# Altium schematic sheet Sheet1.SchDoc
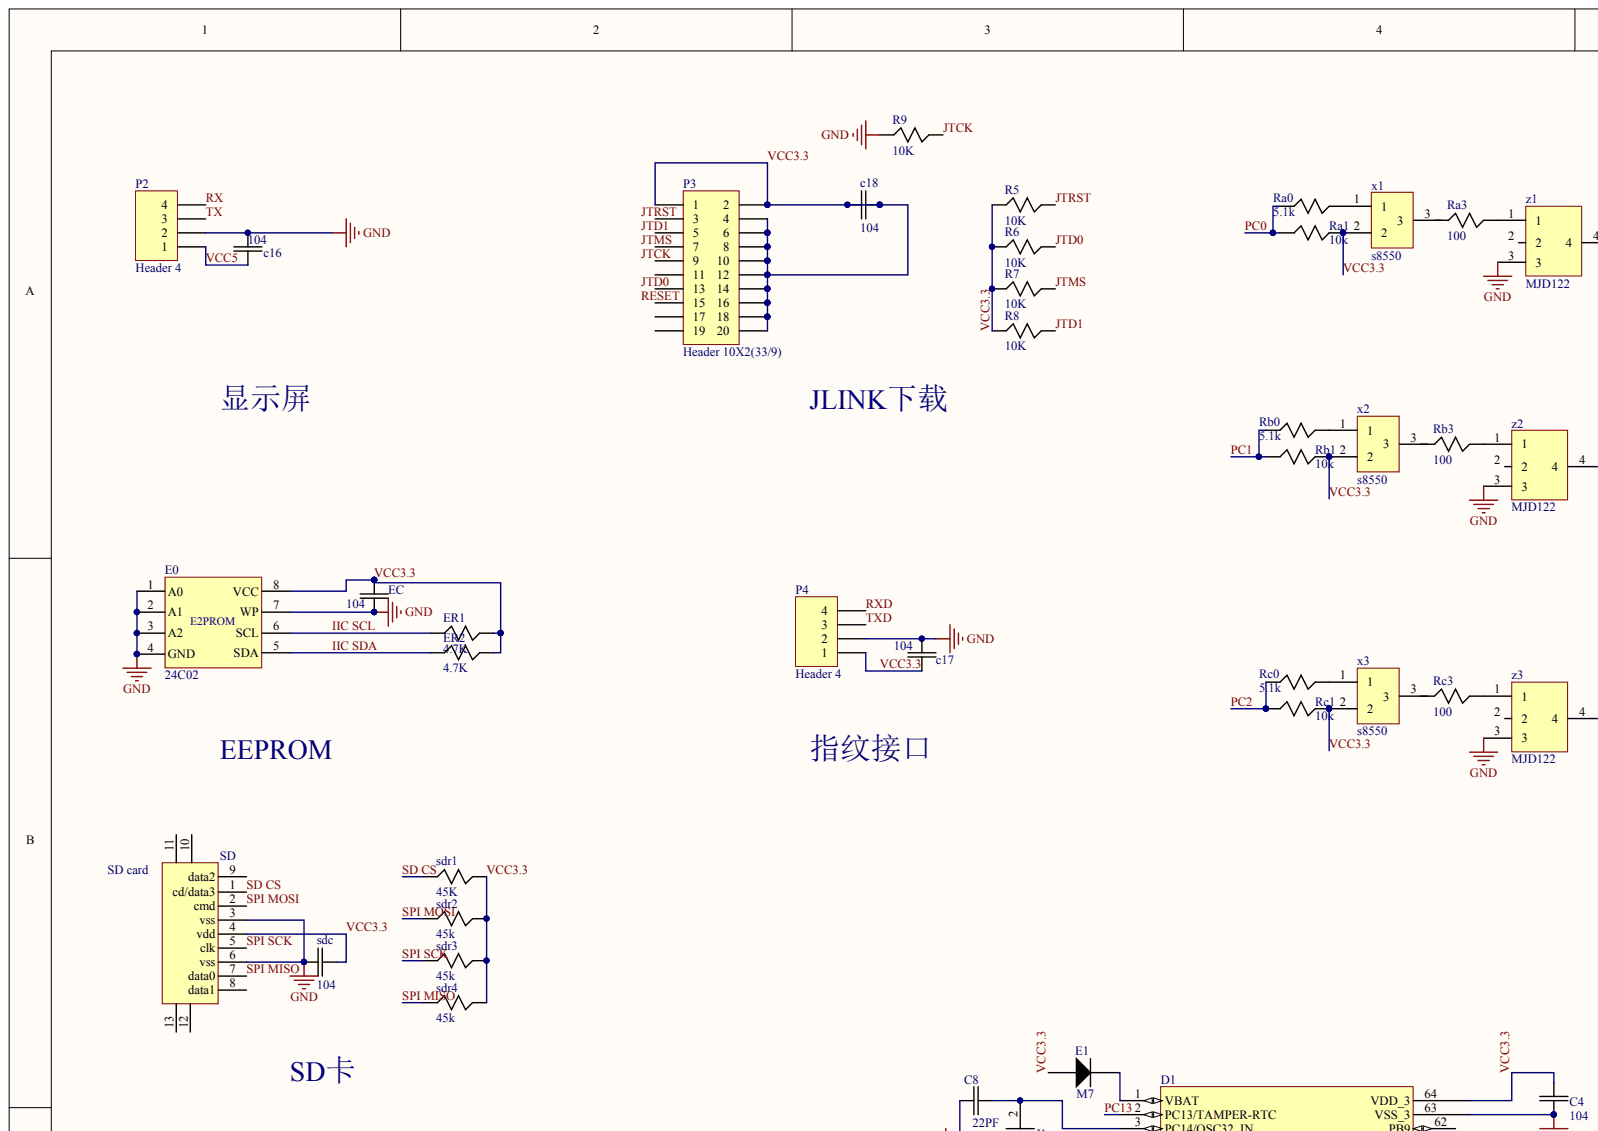
[diagram p0: Sheet1.SchDoc, top left]
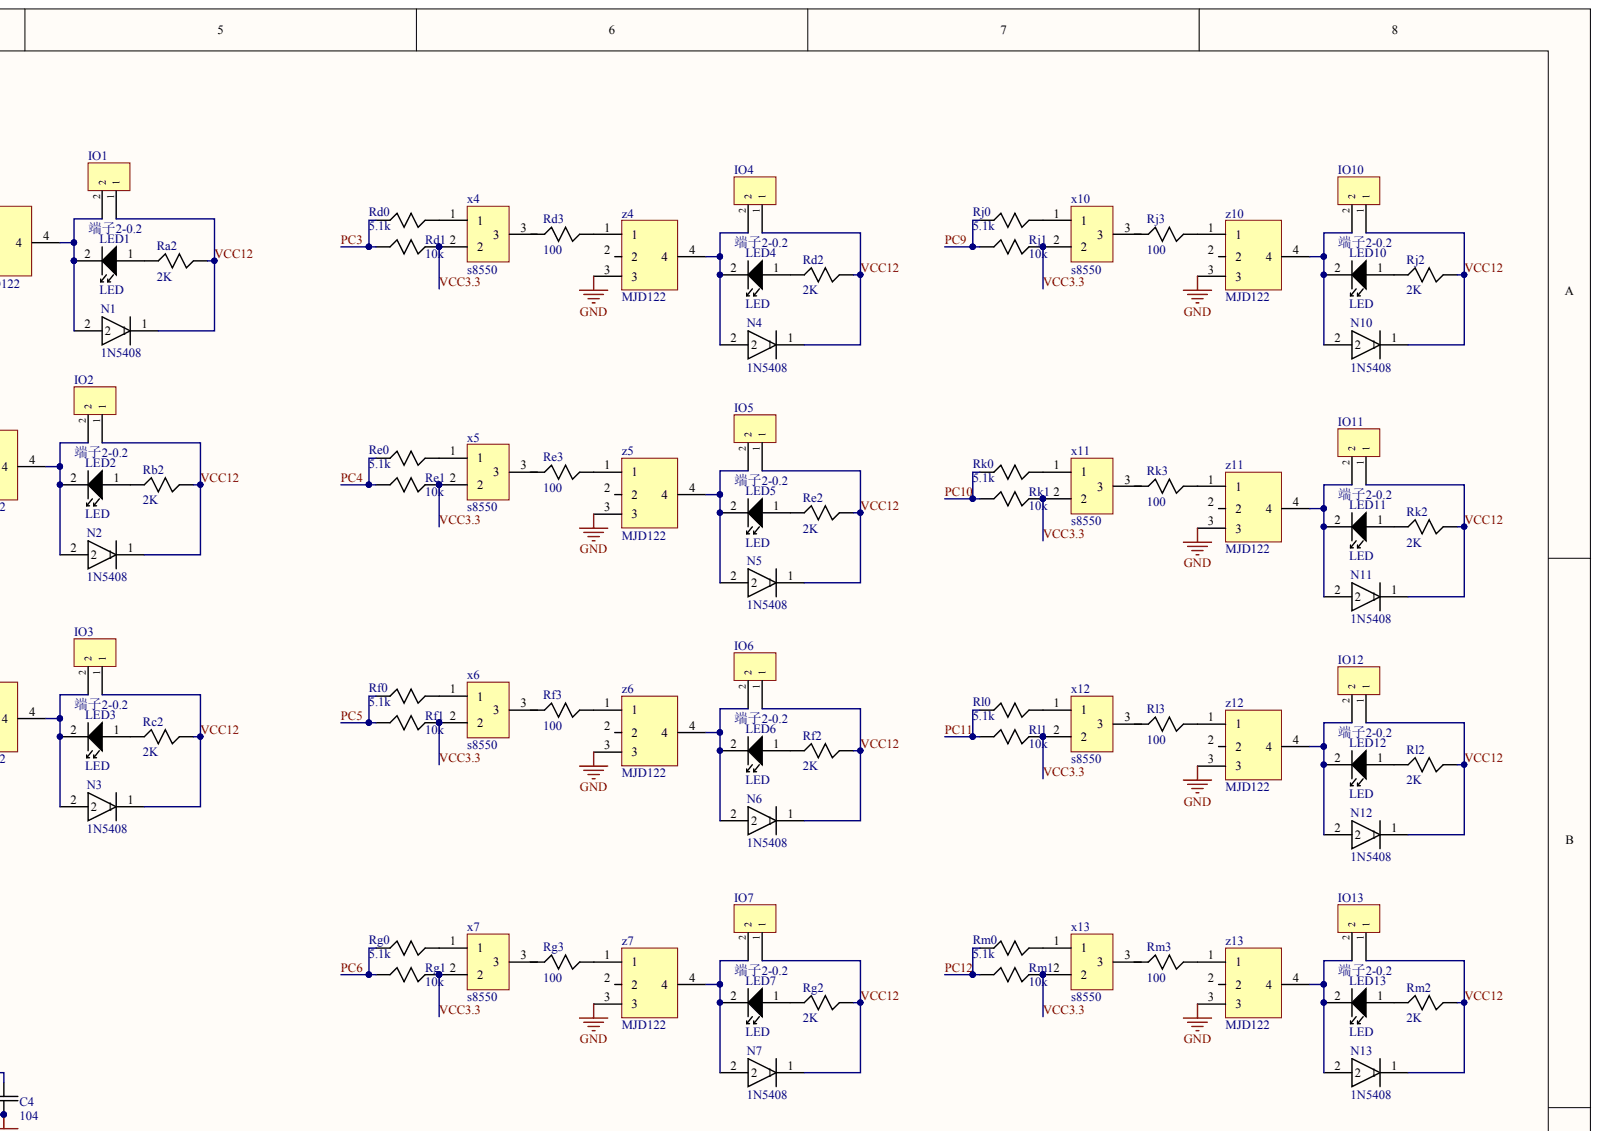
[diagram p1: Sheet1.SchDoc, top right]
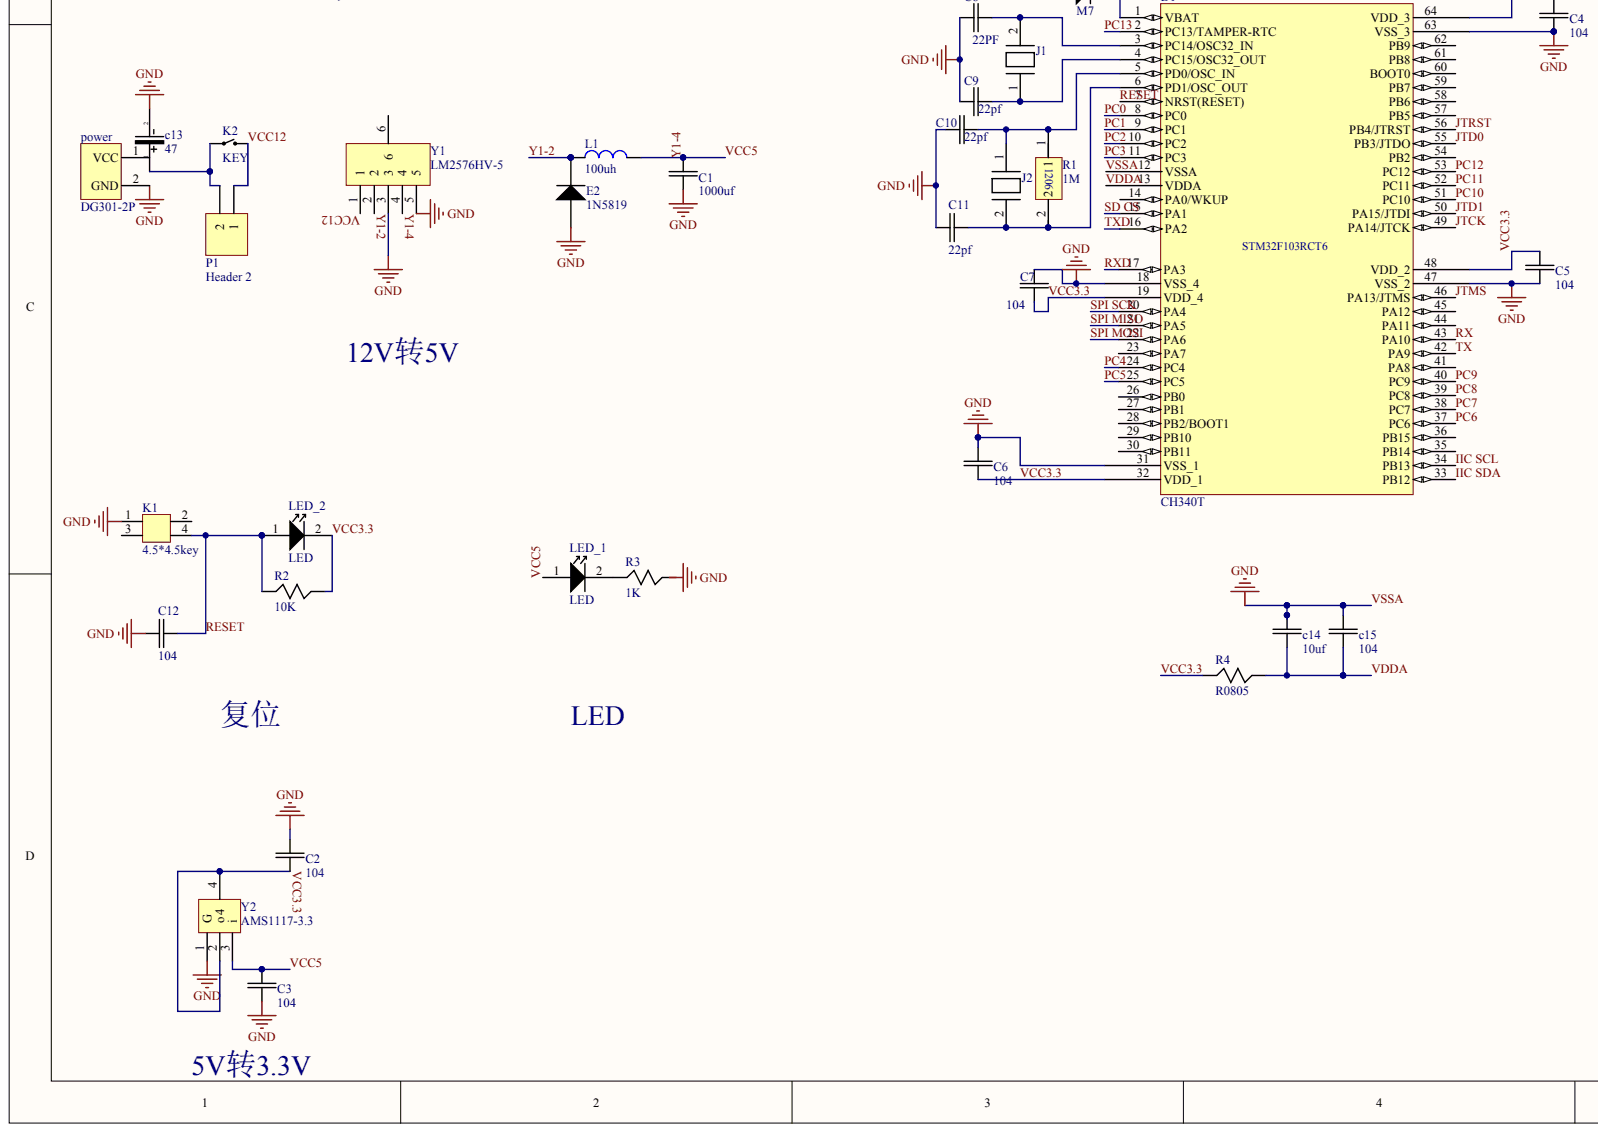
[diagram p2: Sheet1.SchDoc, bottom left]
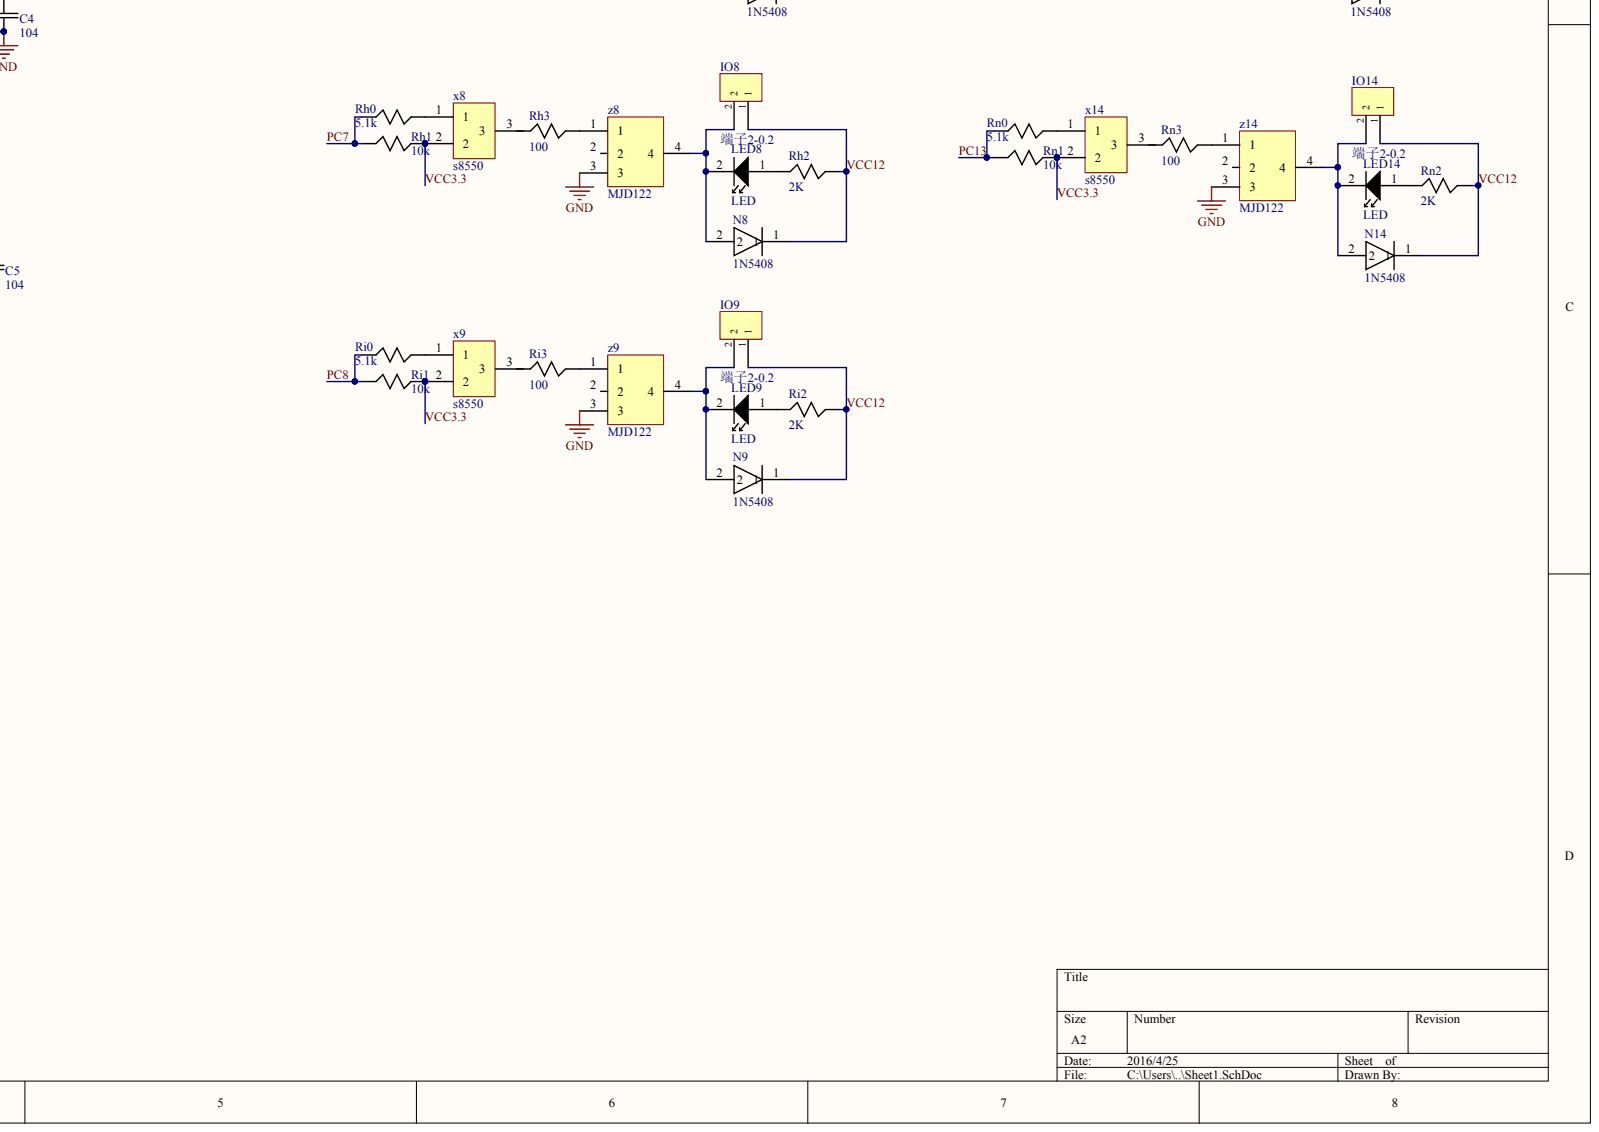
[diagram p3: Sheet1.SchDoc, bottom right]

## Parts
C1  1000uf
C2  104
C3  104
C4  104
C5  104
C6  104
C7  104
C8  22PF
C9  22pf
C10  22pf
C11  22pf
C12  104
c13  47
c14  10uf
c15  104
c16  104
c17  104
c18  104
D1  CH340T
E0  24C02
E1  M7
E2  1N5819
EC  104
ER1  4.7K
ER2  4.7K
IO1  端子2-0.2
IO2  端子2-0.2
IO3  端子2-0.2
IO4  端子2-0.2
IO5  端子2-0.2
IO6  端子2-0.2
IO7  端子2-0.2
IO8  端子2-0.2
IO9  端子2-0.2
IO10  端子2-0.2
IO11  端子2-0.2
IO12  端子2-0.2
IO13  端子2-0.2
IO14  端子2-0.2
J1  32.768K  (value not drawn)
J2  8Õ×  (value not drawn)
K1  4.5*4.5key
K2  KEY
L1  100uh
LED1  LED
LED2  LED
LED3  LED
LED4  LED
LED5  LED
LED6  LED
LED7  LED
LED8  LED
LED9  LED
LED10  LED
LED11  LED
LED12  LED
LED13  LED
LED14  LED
LED_1  LED
LED_2  LED
N1  1N5408
N2  1N5408
N3  1N5408
N4  1N5408
N5  1N5408
N6  1N5408
N7  1N5408
N8  1N5408
N9  1N5408
N10  1N5408
N11  1N5408
N12  1N5408
N13  1N5408
N14  1N5408
P1  Header 2
P2  Header 4
P3  Header 10X2(33/9)
P4  Header 4
power  DG301-2P
R1  1M
R2  10K
R3  1K
R4  R0805
R5  10K
R6  10K
R7  10K
R8  10K
R9  10K
Ra0  5.1k
Ra1  10k
Ra2  2K
Ra3  100
Rb0  5.1k
Rb1  10k
Rb2  2K
Rb3  100
Rc0  5.1k
Rc1  10k
Rc2  2K
Rc3  100
Rd0  5.1k
Rd1  10k
Rd2  2K
Rd3  100
Re0  5.1k
Re1  10k
Re2  2K
Re3  100
Rf0  5.1k
Rf1  10k
Rf2  2K
Rf3  100
Rg0  5.1k
Rg1  10k
Rg2  2K
Rg3  100
Rh0  5.1k
Rh1  10k
Rh2  2K
Rh3  100
Ri0  5.1k
Ri1  10k
Ri2  2K
Ri3  100
Rj0  5.1k
Rj1  10k
Rj2  2K
Rj3  100
Rk0  5.1k
Rk1  10k
Rk2  2K
Rk3  100
Rl0  5.1k
Rl1  10k
Rl2  2K
Rl3  100
Rm0  5.1k
Rm1  10k
Rm2  2K
Rm3  100
Rn0  5.1k
Rn1  10k
Rn2  2K
Rn3  100
SD  SD card
sdc  104
sdr1  45K
sdr2  45k
sdr3  45k
sdr4  45k
x1  s8550
x2  s8550
x3  s8550
x4  s8550
x5  s8550
x6  s8550
x7  s8550
x8  s8550
x9  s8550
x10  s8550
x11  s8550
x12  s8550
x13  s8550
x14  s8550
Y1  LM2576HV-5
Y2  AMS1117-3.3
z1  MJD122
z2  MJD122
z3  MJD122
z4  MJD122
z5  MJD122
z6  MJD122
z7  MJD122
z8  MJD122
z9  MJD122
z10  MJD122
z11  MJD122
z12  MJD122
z13  MJD122
z14  MJD122

## Nets
IIC SCL: D1.34(PB13) E0.6(SCL) ER1.1
IIC SDA: D1.33(PB12) E0.5(SDA) ER2.1
JTCK: D1.49(PA14/JTCK) R9.2
JTD0: D1.55(PB3/JTDO) R6.2
JTD1: D1.50(PA15/JTDI) R8.2
JTMS: D1.46(PA13/JTMS) R7.2
JTRST: D1.56(PB4/JTRST) R5.2
PC0: D1.8(PC0) Ra0.1 Ra1.1
PC1: D1.9(PC1) Rb0.1 Rb1.1
PC2: D1.10(PC2) Rc0.1 Rc1.1
PC3: D1.11(PC3) Rd0.1 Rd1.1
PC4: D1.24(PC4) Re0.1 Re1.1
PC5: D1.25(PC5) Rf0.1 Rf1.1
PC6: D1.37(PC6) Rg0.1 Rg1.1
PC7: D1.38(PC7) Rh0.1 Rh1.1
PC8: D1.39(PC8) Ri0.1 Ri1.1
PC9: D1.40(PC9) Rj0.1 Rj1.1
PC10: D1.51(PC10) Rk0.1 Rk1.1
PC11: D1.52(PC11) Rl0.1 Rl1.1
PC12: D1.53(PC12) Rm0.1 Rm1.1
PC13: D1.2(PC13/TAMPER-RTC) Rn0.1 Rn1.1
RESET: C12.2 D1.7(NRST(RESET)) K1.4 LED_2.1 R2.1
RX: D1.43(PA10) P2.4
RXD: D1.17(PA3) P4.4
SD CS: D1.15(PA1) SD.1(cd/data3) sdr1.1
SPI MISO: D1.21(PA5) SD.7(data0) sdr4.1
SPI MOSI: D1.22(PA6) SD.2(cmd) sdr2.1
SPI SCK: D1.20(PA4) SD.5(clk) sdr3.1
TX: D1.42(PA9) P2.3
TXD: D1.16(PA2) P4.3
VCC3.3: C2.1 C4.1 C5.1 C6.2 C7.2 c17.2 c18.1 c18.2 D1.19(VDD_4) D1.32(VDD_1) D1.48(VDD_2) D1.64(VDD_3) E0.8(VCC) E1.1 EC.2 ER1.2 ER2.2 LED_2.2 P3.1 P3.2 P3.4 P3.6 P3.8 P3.10 P3.12 P3.14 P3.16 P3.18 P3.20 P4.1 R2.2 R4.1 R5.1 R6.1 R7.1 R8.1 Ra1.2 Rb1.2 Rc1.2 Rd1.2 Re1.2 Rf1.2 Rg1.2 Rh1.2 Ri1.2 Rj1.2 Rk1.2 Rl1.2 Rm1.2 Rn1.2 SD.4(vdd) sdc.2 sdr1.2 sdr2.2 sdr3.2 sdr4.2 x1.2 x2.2 x3.2 x4.2 x5.2 x6.2 x7.2 x8.2 x9.2 x10.2 x11.2 x12.2 x13.2 x14.2 Y2.2(o) Y2.4
VCC5: C1.2 C3.2 c16.1 L1.1 LED_1.1 P2.1 Y1.4 Y2.3(i)
VCC12: IO1.1 IO2.1 IO3.1 IO4.1 IO5.1 IO6.1 IO7.1 IO8.1 IO9.1 IO10.1 IO11.1 IO12.1 IO13.1 IO14.1 K2.1 N1.1 N2.1 N3.1 N4.1 N5.1 N6.1 N7.1 N8.1 N9.1 N10.1 N11.1 N12.1 N13.1 N14.1 P1.1 Ra2.2 Rb2.2 Rc2.2 Rd2.2 Re2.2 Rf2.2 Rg2.2 Rh2.2 Ri2.2 Rj2.2 Rk2.2 Rl2.2 Rm2.2 Rn2.2 Y1.1
VDDA: c14.1 c15.1 D1.13(VDDA) R4.2
VSSA: C1.1 C2.2 C3.1 C4.2 C5.2 C6.1 C7.1 C8.1 C9.1 C10.1 C11.1 C12.1 c13.2 c14.2 c15.2 c16.2 c17.1 D1.12(VSSA) D1.18(VSS_4) D1.31(VSS_1) D1.47(VSS_2) D1.63(VSS_3) E0.1(A0) E0.2(A1) E0.3(A2) E0.4(GND) E0.7(WP) E2.1 EC.1 K1.1 P2.2 P4.2 power.2 R9.1 SD.3(vss) SD.6(vss) sdc.1 Y1.3 Y1.5 Y2.1(G) z1.3 z2.3 z3.3 z4.3 z5.3 z6.3 z7.3 z8.3 z9.3 z10.3 z11.3 z12.3 z13.3 z14.3
Y1-2: E2.2 L1.2 Y1.2
NetC8_2: C8.2 D1.3(PC14/OSC32_IN) J1.2
NetC9_2: C9.2 D1.4(PC15/OSC32_OUT) J1.1
NetC10_2: C10.2 D1.5(PD0/OSC_IN) J2.1 R1.1
NetC11_2: C11.2 D1.6(PD1/OSC_OUT) J2.2 R1.2
Netc13_1: c13.1 K2.2 P1.2
NetD1_1: D1.1(VBAT) E1.2
NetIO1_2: IO1.2 LED1.2 N1.2 z1.4
NetIO2_2: IO2.2 LED2.2 N2.2 z2.4
NetIO3_2: IO3.2 LED3.2 N3.2 z3.4
NetIO4_2: IO4.2 LED4.2 N4.2 z4.4
NetIO5_2: IO5.2 LED5.2 N5.2 z5.4
NetIO6_2: IO6.2 LED6.2 N6.2 z6.4
NetIO7_2: IO7.2 LED7.2 N7.2 z7.4
NetIO8_2: IO8.2 LED8.2 N8.2 z8.4
NetIO9_2: IO9.2 LED9.2 N9.2 z9.4
NetIO10_2: IO10.2 LED10.2 N10.2 z10.4
NetIO11_2: IO11.2 LED11.2 N11.2 z11.4
NetIO12_2: IO12.2 LED12.2 N12.2 z12.4
NetIO13_2: IO13.2 LED13.2 N13.2 z13.4
NetIO14_2: IO14.2 LED14.2 N14.2 z14.4
NetLED1_1: LED1.1 Ra2.1
NetLED2_1: LED2.1 Rb2.1
NetLED3_1: LED3.1 Rc2.1
NetLED4_1: LED4.1 Rd2.1
NetLED5_1: LED5.1 Re2.1
NetLED6_1: LED6.1 Rf2.1
NetLED7_1: LED7.1 Rg2.1
NetLED8_1: LED8.1 Rh2.1
NetLED9_1: LED9.1 Ri2.1
NetLED10_1: LED10.1 Rj2.1
NetLED11_1: LED11.1 Rk2.1
NetLED12_1: LED12.1 Rl2.1
NetLED13_1: LED13.1 Rm2.1
NetLED14_1: LED14.1 Rn2.1
NetLED_1_2: LED_1.2 R3.1
NetRa0_2: Ra0.2 x1.1
NetRa3_2: Ra3.2 z1.1
NetRb0_2: Rb0.2 x2.1
NetRb3_2: Rb3.2 z2.1
NetRc0_2: Rc0.2 x3.1
NetRc3_2: Rc3.2 z3.1
NetRd0_2: Rd0.2 x4.1
NetRd3_2: Rd3.2 z4.1
NetRe0_2: Re0.2 x5.1
NetRe3_2: Re3.2 z5.1
NetRf0_2: Rf0.2 x6.1
NetRf3_2: Rf3.2 z6.1
NetRg0_2: Rg0.2 x7.1
NetRg3_2: Rg3.2 z7.1
NetRh0_2: Rh0.2 x8.1
NetRh3_2: Rh3.2 z8.1
NetRi0_2: Ri0.2 x9.1
NetRi3_2: Ri3.2 z9.1
NetRj0_2: Rj0.2 x10.1
NetRj3_2: Rj3.2 z10.1
NetRk0_2: Rk0.2 x11.1
NetRk3_2: Rk3.2 z11.1
NetRl0_2: Rl0.2 x12.1
NetRl3_2: Rl3.2 z12.1
NetRm0_2: Rm0.2 x13.1
NetRm3_2: Rm3.2 z13.1
NetRn0_2: Rn0.2 x14.1
NetRn3_2: Rn3.2 z14.1
N00009:

Not connected: D1 14(PA0/WKUP) 23(PA7) 26(PB0) 27(PB1) 28(PB2/BOOT1) 29(PB10) 30(PB11) 35(PB14) 36(PB15) 41(PA8) 44(PA11) 45(PA12) 54(PB2) 57(PB5) 58(PB6) 59(PB7) 60(BOOT0) 61(PB8) 62(PB9); K1 2 3; P3 3 5 7 9 11 13 15 17 19; power 1; R3 2; Ra3 1; Rb3 1; Rc3 1; Rd3 1; Re3 1; Rf3 1; Rg3 1; Rh3 1; Ri3 1; Rj3 1; Rk3 1; Rl3 1; Rm3 1; Rn3 1; SD 8(data1) 9(data2) 10 11 12 13; x1 3; x2 3; x3 3; x4 3; x5 3; x6 3; x7 3; x8 3; x9 3; x10 3; x11 3; x12 3; x13 3; x14 3; Y1 6; z1 2; z2 2; z3 2; z4 2; z5 2; z6 2; z7 2; z8 2; z9 2; z10 2; z11 2; z12 2; z13 2; z14 2

## Library data of the parts
C1-C12 c13-c18 D1 E0-E2 EC ER1 ER2 IO1-IO14 J1 J2 K1 K2 L1 LED1-LED14 LED_1 LED_2 N1-N14 P1-P4 power R1-R9 Ra0-Ra3 Rb0-Rb3 Rc0-Rc3 Rd0-Rd3 Re0-Re3 Rf0-Rf3 Rg0-Rg3 Rh0-Rh3 Ri0-Ri3 Rj0-Rj3 Rk0-Rk3 Rl0-Rl3 Rm0-Rm3 Rn0-Rn3 SD sdc sdr1-sdr4 x1-x14 Y1 Y2 z1-z14 = (no symbol name)
  P1-P4: LatestRevisionDate: 17-Jul-2002; LatestRevisionNote: Re-released for DXP Platform.; Publisher: Altium Limited
